# Altium schematic sheet Power.SchDoc(Power)
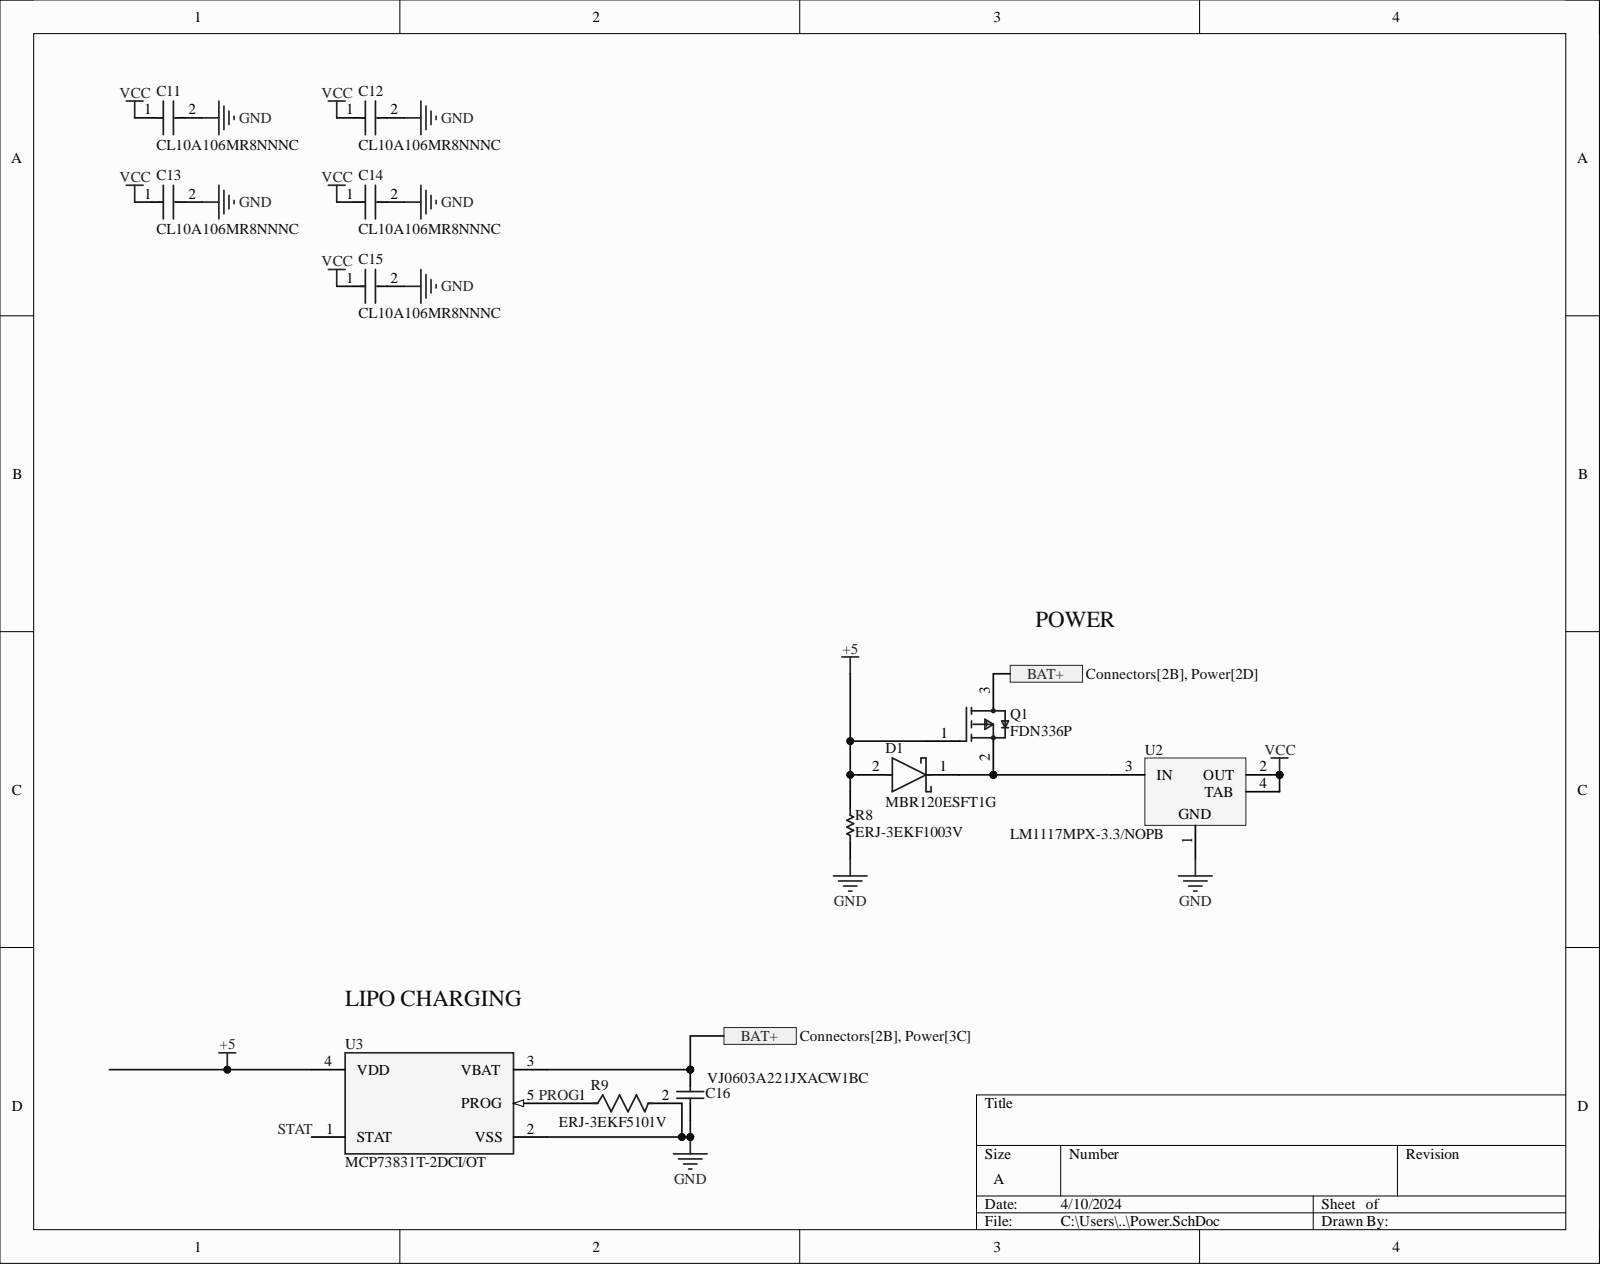
[diagram p0: Power.SchDoc]

## Parts
C11  CL10A106MR8NNNC  [FP-CL10-IPC_C]
C12  CL10A106MR8NNNC  [FP-CL10-IPC_C]
C13  CL10A106MR8NNNC  [FP-CL10-IPC_C]
C14  CL10A106MR8NNNC  [FP-CL10-IPC_C]
C15  CL10A106MR8NNNC  [FP-CL10-IPC_C]
C16  VJ0603A221JXACW1BC  [CAPC1608X95X40ML15T15]
D1  MBR120ESFT1G  [On_Semiconductor_498_MFG]
Q1  FDN336P  [FP-527AG-IPC_A]
R8  ERJ-3EKF1003V  [RESC1608X55X30ML15T15]
R9  ERJ-3EKF5101V  [FP-ERJ3EK-MFG]
U2  LM1117MPX-3.3/NOPB  [DCY0004A_L]
U3  MCP73831T-2DCI/OT  [FP-C04-091-OT-IPC_B]

## Ports
BAT+

## Nets
+5: D1.2 Q1.1 R8.2 U3.4(VDD)
GND: C11.2 C12.2 C13.2 C14.2 C15.2 C16.1 R8.1 R9.2 U2.1 U3.2(VSS)
VCC: C11.1 C12.1 C13.1 C14.1 C15.1 U2.2(OUT) U2.4(TAB)
BAT+: C16.2 Q1.3 U3.3(VBAT) ; port BAT+
NetD1_1: D1.1 Q1.2 U2.3(IN)
NetR9_1: R9.1 U3.5(PROG)
PROG:
STAT:

Not connected: U3 1(STAT)

## Library data of the parts
C11-C15 = CMP-13271-001990-1: Cap Ceramic 10uF 4V X5R ±20% Pad SMD 0603 +85°C T/R; Capacitance: 10uF; Case Code (Imperial): 0603; Case Code (Metric): 1608; Case/Package: 0603; Component Kind: Standard; Depth: 0.8mm; Dielectric: X5R; Height: 0.8mm; Lead Free: Lead Free; Length: 1.6mm; Library Name: Altium Content Vault; Max Operating Temperature: 85°C; Min Operating Temperature: -55°C; Mount: Surface Mount; Package Quantity: 1; Packaging: Tape and Reel; Radiation Hardening: No; Thickness: 0.035inch; Tolerance: 20%; Voltage Rating: 4V; Voltage Rating (DC): 4V; Width: 0.031inch
C16 = CMP-2006-00030-1: Manufacturer: Vitramon; Component Kind: Standard; Library Name: Altium Content Vault; Part Number: VJ0603A221JXACW1BC
D1 = CMP-1055-00434-2: Manufacturer Lifecycle Status: ACTIVE; NexarPartId: 330787; Average Rectified Current: 1A; Element Configuration: Single; Forward Voltage: 530mV; Max Forward Surge Current (Ifsm): 40A; Max Junction Temperature (Tj): 150°C; Max Repetitive Reverse Voltage (Vrrm): 20V; Max Reverse Leakage Current: 10µA; Polarity: Standard; Component Kind: Standard; Contact Plating: Tin; Current Rating: 1A; Forward Current: 1A; Halogen Free: Halogen Free; Height: 880µm; Lead Free: Lead Free; Length: 2.9mm; Library Name: Altium Content Vault; Lifecycle Status: Production; Max Operating Temperature: 150°C; Max Reverse Voltage (DC): 20V; Max Surge Current: 40A; Min Operating Temperature: -65°C; Number of Pins: 2; Output Current: 1A; Packaging: Cut Tape; Peak Non-Repetitive Surge Current: 40A; Peak Reverse Current: 10µA; Radiation Hardening: No; REACH SVHC: No SVHC; Reverse Voltage: 20V; RoHS: Compliant; Schedule B: 8541100080; Voltage Rating (DC): 20V; Width: 1.8mm
Q1 = CMP-07173-000103-1: MOSFET P-CH 20V 1.3A SSOT3; Current Rating: -1.3A; Drain to Source Breakdown Voltage: -20V; Drain to Source Resistance: 200mR; Element Configuration: Single; Fall Time: 12ns; Gate to Source Voltage (Vgs): 8V; Input Capacitance: 330pF; Max Power Dissipation: 500mW; Nominal Vgs: -900mV; Number of Elements: 1; Turn-Off Delay Time: 16ns; Turn-On Delay Time: 7ns; Case/Package: TO-236-3; Component Kind: Standard; Contact Plating: Tin; Continuous Drain Current (ID): 1.3A; Drain to Source Voltage (Vdss): 20V; Dual Supply Voltage: -20V; Height: 0.94mm; Lead Free: Lead Free; Length: 2.92mm; Library Name: Altium Content Vault; Max Operating Temperature: 150°C; Min Operating Temperature: -55°C; Mount: Surface Mount; Number of Pins: 3; On-State Resistance: 200mR; Package Quantity: 1; Packaging: Tape and Reel; Power Dissipation: 500mW; Radiation Hardening: No; Rds On Max: 200mR; REACH SVHC: No SVHC; Rise Time: 12ns; Termination: SMD/SMT; Threshold Voltage: -900mV; Voltage Rating (DC): -20V; Weight: 0.03g; Width: 1.4mm
R8 = CMP-2000-00182-1: Manufacturer: Panasonic; Component Kind: Standard; Library Name: Altium Content Vault; Part Number: ERJ-3EKF1003V
R9 = CMP-2000-00540-8: RES SMD 5.1K OHM 1% 1/10W 0603; Max Power Dissipation: 100mW; Resistance: 5.1kR; Temperature Coefficient: 100ppm/°C; Voltage Rating: 75V; Case Code (Imperial): 0603; Case Code (Metric): 1608; Case/Package: 0603; Component Kind: Standard; Construction: Rectangular; Contact Plating: Tin; Depth: 0.8mm; Features: Automotive AEC-Q200; Height: 0.022inch; Lead Free: Lead Free; Length: 1.6mm; Library Name: Altium Content Vault; Max Operating Temperature: 155°C; Military Standard: Not; Min Operating Temperature: -55°C; Mount: Surface Mount; Number of Terminations: 2; Package Quantity: 1; Packaging: Tape and Reel; Power Rating: 100mW; Radiation Hardening: No; Reach SVHC Compliant: Yes; Resistance Tolerance: 1%; Resistor Type: Thick Film; RoHS Compliant: Yes; Series: ERJ; Size Code: 0603; Termination: SMD/SMT; Tolerance: 1%; Voltage Rating (DC): 75V; Weight: 7.1E-05oz; Width: 0.8mm
U2 = CMP-0062-01639-4: 800mA Low-Dropout Linear Regulator, 4-pin SOT-223, Pb-Free; Manufacturer: Texas Instruments; CompType: LDO IC; High-Reliability: Y; PackageTopMarking: XYTT*N05A; PowerWise: N; Code_IPC: SOT223-4; Code_JEDEC: TO-261-AA; Component Kind: Standard; ComponentLink1Description: Datasheet URL; ComponentLink1URL: http://www.ti.com/general/docs/lit/getliterature.tsp?genericPartNumber=LM1117-N&fileType=pdf; Library Name: Altium Content Vault; PackageDescription: Molded SOT-223, 6.50 x 3.56 x 1.6mm Body, 4 Lead, 2.3mm Pitch; PackageReference: DCY0004A; PartNumber: LM1117MPX-3.3/NOPB; PinCount: 4; RoHS: Y
U3 = CMP-0133-00146-2: IC CONTROLLR LI-ION 4.2V SOT23-5; Max Charge Current: 500mA; Max Input Voltage: 3.75V; Max Output Voltage: 4.232V; Max Supply Voltage: 6V; Min Output Voltage: 4.168V; Number of Cells: 1; Operating Supply Current: 510uA; Case/Package: SOT-23; Component Kind: Standard; Contact Plating: Tin; Height: 1.3mm; Lead Free: Lead Free; Length: 3.1mm; Library Name: Altium Content Vault; Max Operating Temperature: 85°C; Max Output Current: 500mA; Min Operating Temperature: -40°C; Min Supply Voltage: 3.75V; Mount: Surface Mount; Number of Channels: 1; Number of Pins: 5; Output Current: 500mA; Output Voltage: 4.2V; Package Quantity: 3000; Packaging: Tape and Reel; Radiation Hardening: No; REACH SVHC: No SVHC; Width: 1.8mm
